# Altium schematic sheet Sheet1.SchDoc
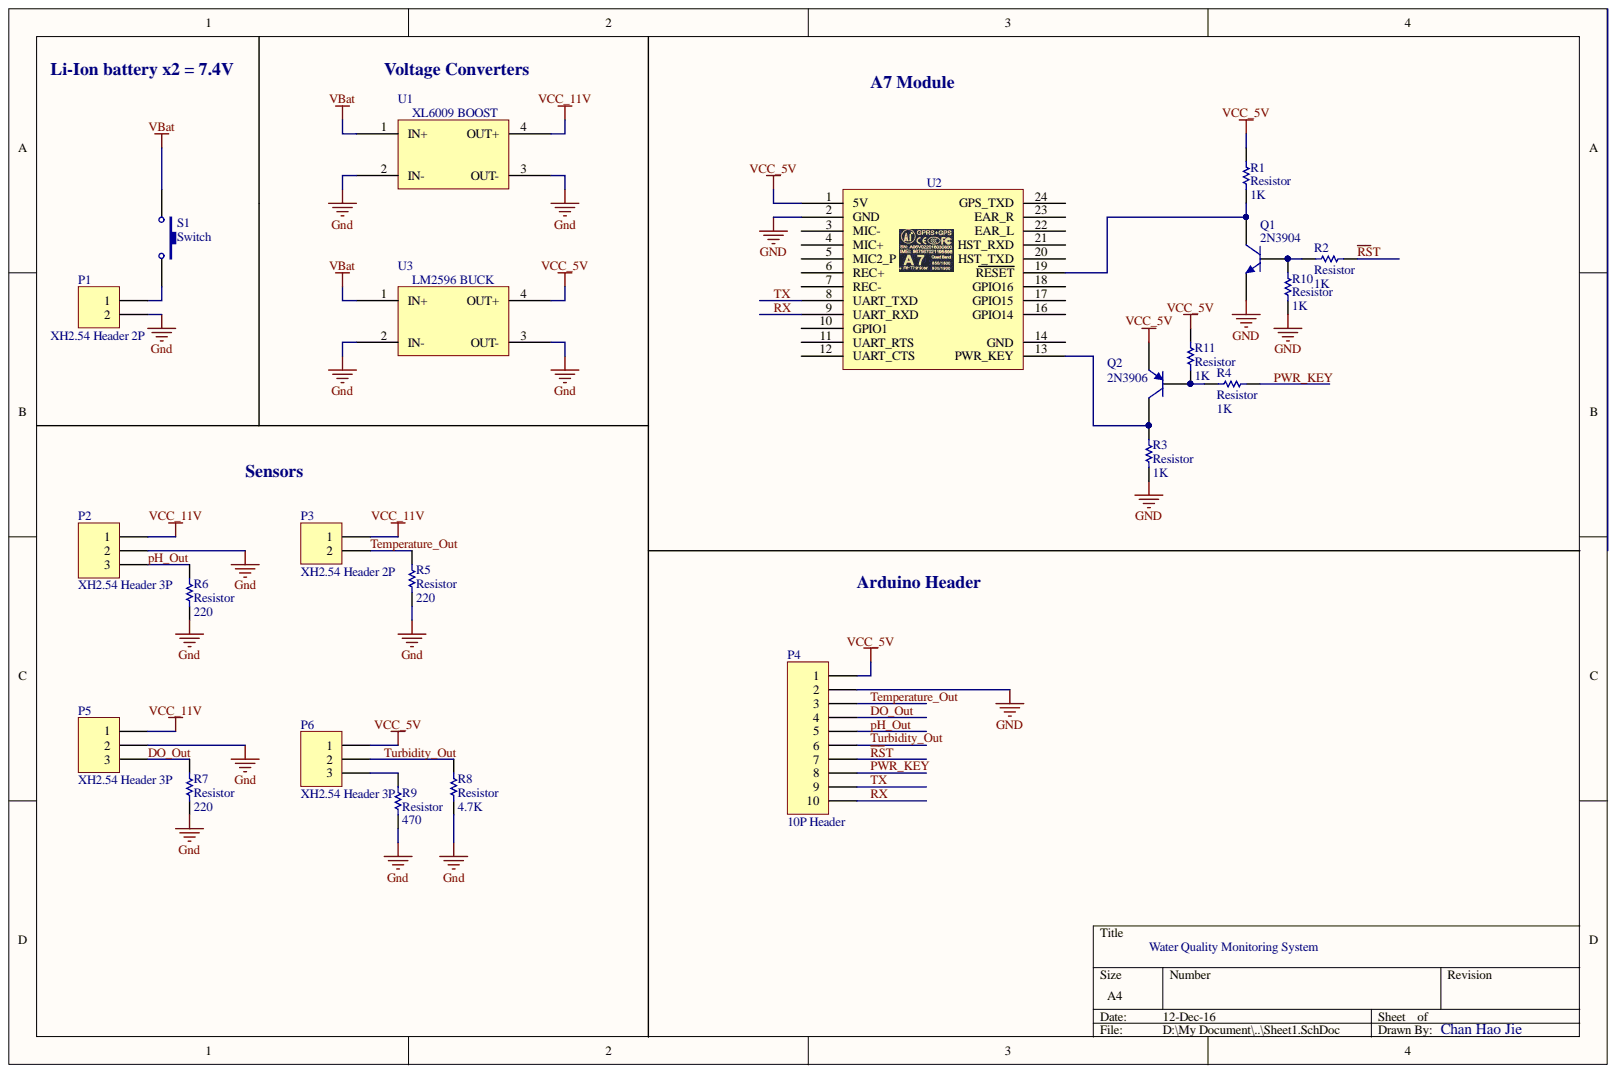
[diagram p0: Sheet1.SchDoc]

## Parts
P1  XH2.54 Header 2P  [XH2.54-2P]
P2  XH2.54 Header 3P  [XH2.54-3P]
P3  XH2.54 Header 2P  [XH2.54-2P]
P4  10P Header  [10P Header]
P5  XH2.54 Header 3P  [XH2.54-3P]
P6  XH2.54 Header 3P  [XH2.54-3P]
Q1  2N3904  [TO-92A]
Q2  2N3906  [TO-92A]
R1  Resistor  value 1K  [AXIAL-0.3]
R2  Resistor  value 1K  [AXIAL-0.3]
R3  Resistor  value 1K  [AXIAL-0.3]
R4  Resistor  value 1K  [AXIAL-0.3]
R5  Resistor  value 220  [AXIAL-0.3]
R6  Resistor  value 220  [AXIAL-0.3]
R7  Resistor  value 220  [AXIAL-0.3]
R8  Resistor  value 4.7K  [AXIAL-0.3]
R9  Resistor  value 470  [AXIAL-0.3]
R10  Resistor  value 1K  [AXIAL-0.3]
R11  Resistor  value 1K  [AXIAL-0.3]
S1  Switch  [SPST-2]
U1  XL6009  [XL6009 Boost]
U2  A7 GSM/GPRS/GPS Module Breakout Board  [A7 GSM/GPS]  (value not drawn)
U3  LM2596 Buck Converter  [LM2596 Buck]  (value not drawn)

## Nets
GND: P1.2 P2.2 P4.2 P5.2 Q1.1 R3.2 R5.1 R6.1 R7.1 R8.1 R9.1 R10.2 U1.2(IN-) U1.3(OUT-) U2.2(GND) U3.2(IN-) U3.3(OUT-)
VBAT: S1.1 U1.1(IN+) U3.1(IN+)
VCC_5V: P4.1 P6.1 Q2.1 R1.2 R11.1 U2.1(5V) U3.4(OUT+)
VCC_11V: P2.1 P3.1 P5.1 U1.4(OUT+)
DO_OUT: P4.4 P5.3 R7.2
PH_OUT: P2.3 P4.5 R6.2
PWR_KEY: P4.8 R4.1
~{RS}T: P4.7 R2.1
RX: P4.10 U2.9(UART_RXD)
TEMPERATURE_OUT: P3.2 P4.3 R5.2
TURBIDITY_OUT: P4.6 P6.2 R8.2
TX: P4.9 U2.8(UART_TXD)
NetP1_1: P1.1 S1.2
NetP6_3: P6.3 R9.2
NetQ1_2: Q1.2 R2.2 R10.1
NetQ1_3: Q1.3 R1.1 U2.19(RESET)
NetQ2_2: Q2.2 R4.2 R11.2
NetQ2_3: Q2.3 R3.1 U2.13(PWR_KEY)
N00003:

Not connected: U2 3(MIC-) 4(MIC+) 5(MIC2_P) 6(REC+) 7(REC-) 10(GPIO1) 11(UART_RTS) 12(UART_CTS) 14(GND) 16(GPIO14) 17(GPIO15) 18(GPIO16) 20(HST_TXD) 21(HST_RXD) 22(EAR_L) 23(EAR_R) 24(GPS_TXD)

## Library data of the parts
P1 P3 = XH2.54-2P: Component Kind: Standard; Library Name: External Module.SchLib
P2 P5 P6 = XH2.54-3P: Component Kind: Standard; Library Name: External Module.SchLib
Q1 = 2N3904: NPN General Purpose Amplifier; Component Kind: Standard; ComponentLink1Description: Manufacturer Link; ComponentLink1URL: http://www.fairchildsemi.com/; ComponentLink2Description: Datasheet; ComponentLink2URL: http://www.fairchildsemi.com/ds/2N%2F2N3904.pdf; DatasheetVersion: 1997; LatestRevisionDate: 15-Jan-2003; LatestRevisionNote: PCB Footprint 'BCY-W3/D4.7' replaced; Library Name: Miscellaneous Devices.IntLib; PackageDescription: TO, Flat Index; 3 In-Line, Axial Leads; Body Dia. 4.5mm; Leads 0.51 x 0.48 mm (max); PackageReference: TO-92A; PackageVersion: Sep-1998; Published: 8-Jun-2000; Signal Integrity: 2N3904; Simulation: 2N3904; Publisher: Altium Limited
Q2 = 2N3906: PNP General Purpose Amplifier; Component Kind: Standard; ComponentLink1Description: Manufacturer Link; ComponentLink1URL: http://www.fairchildsemi.com/; ComponentLink2Description: Datasheet; ComponentLink2URL: http://www.fairchildsemi.com/ds/2N%2F2N3906.pdf; DatasheetVersion: 1997; LatestRevisionDate: 15-Jan-2003; LatestRevisionNote: PCB Footprint 'BCY-W3/D4.7' replaced; Library Name: Miscellaneous Devices.IntLib; PackageDescription: TO, Flat Index; 3 In-Line, Axial Leads; Body Dia. 4.5mm; Leads 0.51 x 0.48 mm (max); PackageReference: TO-92A; PackageVersion: Sep-1998; Published: 8-Jun-2000; Signal Integrity: 2N3906; Simulation: 2N3906; Publisher: Altium Limited
R2 = Resistor: Value: 1K; Component Kind: Standard; Description: Resistor; Library Name: External Module.SchLib
P4 = 10P Header: Component Kind: Standard; Library Name: External Module.SchLib
R1 R3-R11 = Resistor: Component Kind: Standard; Description: Resistor; Library Name: External Module.SchLib
S1 = Switch: Component Kind: Standard; Library Name: External Module.SchLib
U1 = XL6009: Component Kind: Standard; Library Name: External Module.SchLib
U2 = A7 GSM/GPRS/GPS Module Breakout Board: Component Kind: Standard; Description: A7 GSM/GPRS/GPS Module Breakout Board; Library Name: External Module.SchLib
U3 = LM2596 Buck Converter: Component Kind: Standard; Library Name: External Module.SchLib
